# Altium schematic sheet vibration_sensor.SchDoc
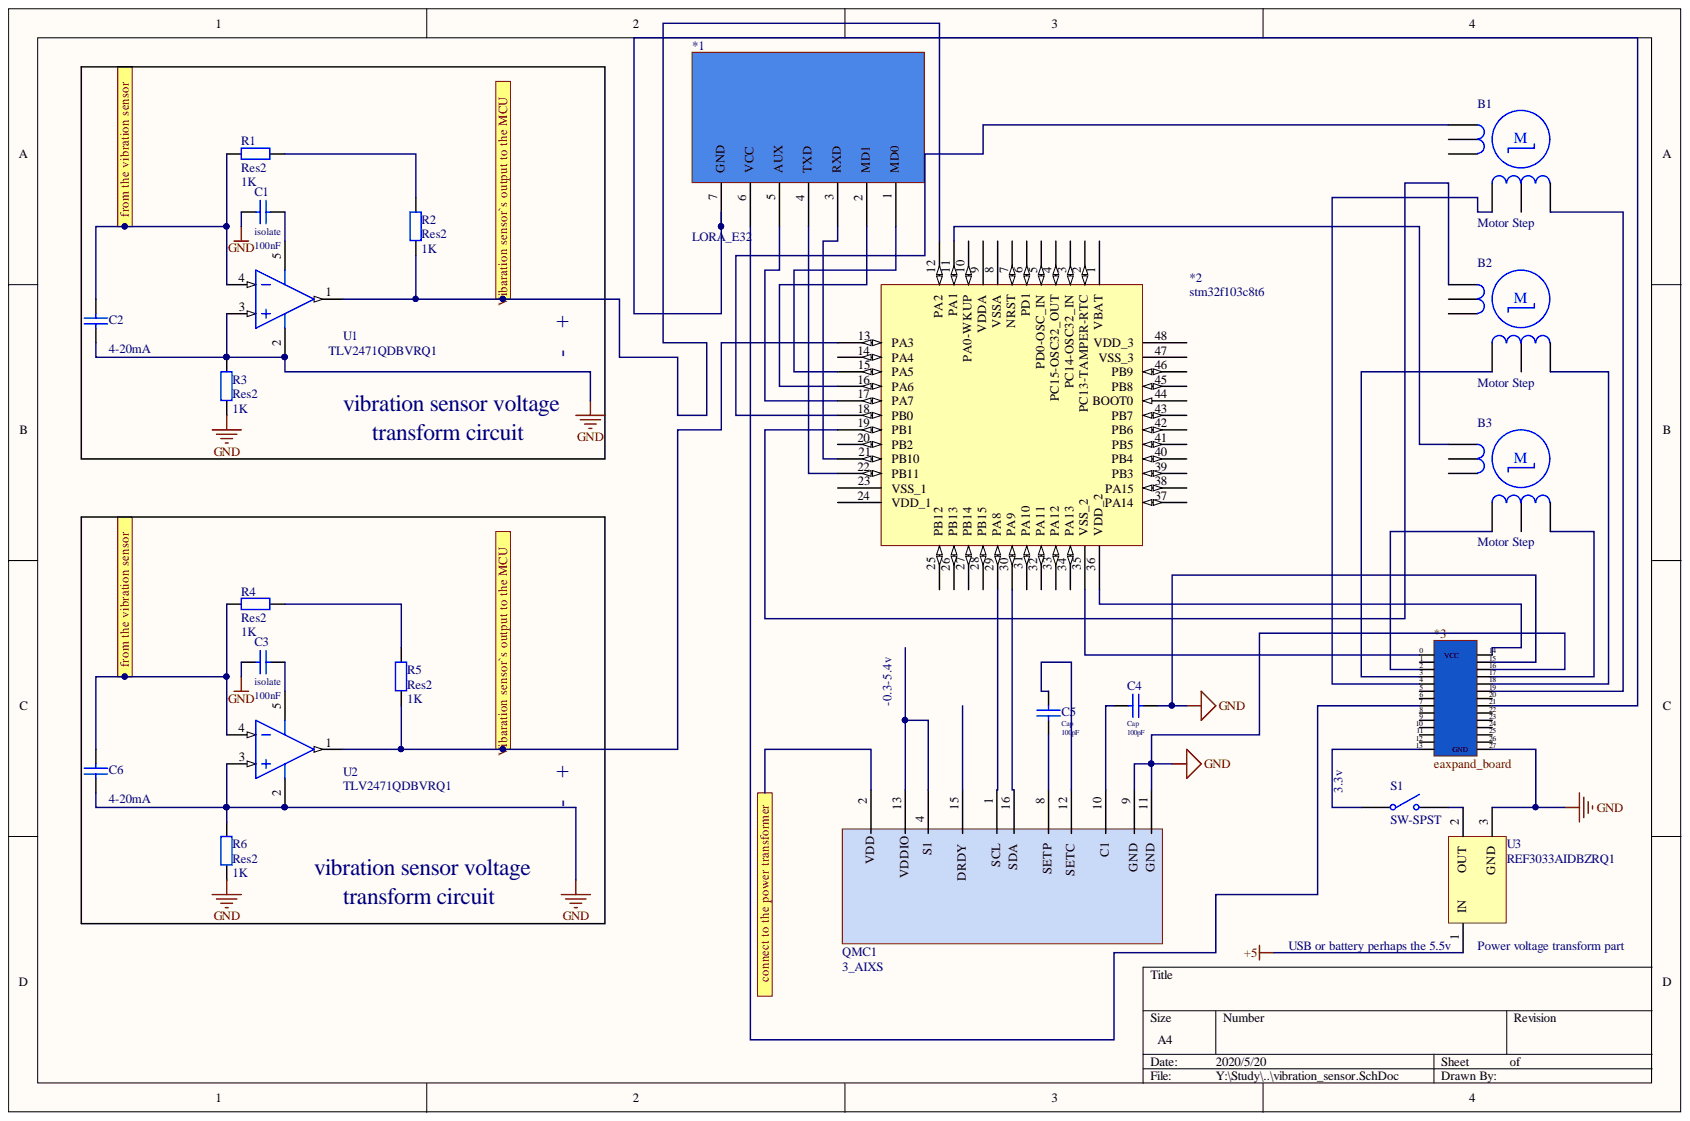
[diagram p0: vibration_sensor.SchDoc]

## Parts
*1  LORA_E32  [LORA_AS62-T20]
*2  stm32f103c8t6
*3  eaxpand_board  [expand_board]
B1  Motor Step  [DIP-6]
B2  Motor Step  [DIP-6]
B3  Motor Step  [DIP-6]
C1  isolate  value 100nF  [RAD-0.3]
C2  value 4-20mA  [RAD-0.3]  (hidden comment: 假装这是一个电流源)
C3  isolate  value 100nF  [RAD-0.3]
C4  Cap  value 100pF  [RAD-0.3]
C5  Cap  value 100pF  [RAD-0.3]
C6  value 4-20mA  [RAD-0.3]  (hidden comment: 假装这是一个电流源)
QMC1  3_AIXS
R1  Res2  value 1K  [AXIAL-0.4]
R2  Res2  value 1K  [AXIAL-0.4]
R3  Res2  value 1K  [AXIAL-0.4]
R4  Res2  value 1K  [AXIAL-0.4]
R5  Res2  value 1K  [AXIAL-0.4]
R6  Res2  value 1K  [AXIAL-0.4]
S1  SW-SPST  [SPST-2]
U1  TLV2471QDBVRQ1  [TI-DBV5_N]
U2  TLV2471QDBVRQ1  [TI-DBV5_N]
U3  REF3033AIDBZRQ1  [TI-DBZ3_N]

## Ports
connect to the power transformer, from the vibration sensor, Vibaration sensor`s output to the MCU

## Nets
+5: U3.1
GND: *3.15 *3.16 *3.27(GND) C1.2 C2.1 C3.2 C4.1 C6.1 QMC1.9(GND) QMC1.11(GND) R3.1 R3.2 R6.1 R6.2 U1.2 U1.3 U2.2 U2.3 U3.3
connect to the power transformer: QMC1.2(VDD) ; port connect to the power transformer
from the vibration sensor: C2.2 C6.2 R1.1 R4.1 U1.4 U2.4 ; port from the vibration sensor
Vibaration sensor`s output to the MCU: *2.12(PA2) *2.13(PA3) R2.1 R5.1 U1.1 U2.1 ; port Vibaration sensor`s output to the MCU
Net*1_1: *1.1(MD0) *2.15(PA5)
Net*1_2: *1.2(MD1) *2.16(PA6)
Net*1_3: *1.3(RXD) *2.21(PB10)
Net*1_4: *1.4(TXD) *2.22(PB11)
Net*1_5: *1.5(AUX) *2.17(PA7)
Net*1_6: *1.6(VCC) *3.7
Net*1_7: *1.7(GND) *3.21
Net*2_11: *2.11(PA1) B3.1
Net*2_18: *2.18(PB0) B1.1
Net*2_19: *2.19(PB1) B2.1
Net*2_29: *2.29(PA8) QMC1.1(SCL)
Net*2_30: *2.30(PA9) QMC1.16(SDA)
Net*2_35: *2.35(VSS_2) *3.0(VCC)
Net*2_36: *2.36(VDD_2) *3.14
Net*3_2: *3.2 B3.4
Net*3_3: *3.3 B2.4
Net*3_4: *3.4 B1.4
Net*3_13: *3.13 S1.1
Net*3_17: *3.17 B3.6
Net*3_18: *3.18 B2.6
Net*3_19: *3.19 B1.6
NetC1_1: C1.1 U1.5
NetC3_1: C3.1 U2.5
NetC4_2: C4.2 QMC1.10(C1)
NetC5_1: C5.1 QMC1.8(SETP)
NetC5_2: C5.2 QMC1.12(SETC)
NetQMC1_4: QMC1.4(S1) QMC1.13(VDDIO)
NetR1_2: R1.2 R2.2
NetR4_2: R4.2 R5.2
NetS1_2: S1.2 U3.2

Not connected: *2 1(VBAT) 2(PC13-TAMPER-RTC) 3(PC14-OSC32_IN) 4(PC15-OSC32_OUT) 5(PD0-OSC_IN) 6(PD1) 7(NRST) 8(VSSA) 9(VDDA) 10(PA0-WKUP) 14(PA4) 20(PB2) 23(VSS_1) 24(VDD_1) 25(PB12) 26(PB13) 27(PB14) 28(PB15) 31(PA10) 32(PA11) 33(PA12) 34(PA13) 37(PA14) 38(PA15) 39(PB3) 40(PB4) 41(PB5) 42(PB6) 43(PB7) 44(BOOT0) 45(PB8) 46(PB9) 47(VSS_3) 48(VDD_3); *3 1 5 6 8 9 10 11 12 20 22 23 24 25 26; B1 2 3 5; B2 2 3 5; B3 2 3 5; QMC1 15(DRDY)

## Library data of the parts
*1 = LORA_E32: EBYTE_USE_SEMTCH_CORE; Component Kind: Standard; Library Name: lora.SchLib
B1-B3 = Motor Step: Motor Stepper; Code_JEDEC: MO-001-AM; Component Kind: Standard; LatestRevisionDate: 17-Jul-2002; LatestRevisionNote: Re-released for DXP Platform.; Library Name: Miscellaneous Devices.IntLib; PackageReference: DIP-6; Published: 24-Mar-1999; Publisher: Altium Limited
C1 C3 = Cap: Capacitor; Value: 100nF; Component Kind: Standard; LatestRevisionDate: 17-Jul-2002; LatestRevisionNote: Re-released for DXP Platform.; Library Name: Miscellaneous Devices.IntLib; PackageDescription: Radial Cap, Thru-Hole; 2 Leads; 0.3 in Pin Spacing; PackageReference: RAD-0.3; Published: 8-Jun-2000; Signal Integrity: Cap; Simulation: CAP; Publisher: Altium Limited
C2 C4-C6 = Cap: Capacitor; Component Kind: Standard; LatestRevisionDate: 17-Jul-2002; LatestRevisionNote: Re-released for DXP Platform.; Library Name: Miscellaneous Devices.IntLib; PackageDescription: Radial Cap, Thru-Hole; 2 Leads; 0.3 in Pin Spacing; PackageReference: RAD-0.3; Published: 8-Jun-2000; Signal Integrity: Cap; Simulation: CAP; Publisher: Altium Limited
QMC1 = QMC5883L: Component Kind: Standard; Library Name: QMC5883L.SchLib
R1 R4 = Res2: Resistor; Value: 1K; Component Kind: Standard; LatestRevisionDate: 17-Jul-2002; LatestRevisionNote: Re-released for DXP Platform.; Library Name: Miscellaneous Devices.IntLib; PackageDescription: Axial Device, Thru-Hole; 2 Leads; 0.4 in Pin Spacing; PackageReference: AXIAL-0.4; Published: 8-Jun-2000; Signal Integrity: Res; Simulation: RESISTOR; Publisher: Altium Limited
R2 R3 R5 R6 = Res2: Resistor; Component Kind: Standard; LatestRevisionDate: 17-Jul-2002; LatestRevisionNote: Re-released for DXP Platform.; Library Name: Miscellaneous Devices.IntLib; PackageDescription: Axial Device, Thru-Hole; 2 Leads; 0.4 in Pin Spacing; PackageReference: AXIAL-0.4; Published: 8-Jun-2000; Signal Integrity: Res; Simulation: RESISTOR; Publisher: Altium Limited
S1 = SW-SPST: Single-Pole, Single-Throw Switch; Component Kind: Standard; LatestRevisionDate: 17-Jul-2002; LatestRevisionNote: Re-released for DXP Platform.; Library Name: Miscellaneous Devices.IntLib; PackageDescription: Switch; 2 Leads; PackageReference: SPST-2; Published: 24-Mar-1999; Publisher: Altium Limited
U1 U2 = TLV2471QDBVRQ1: Automotive Catalog 600 uA/Ch 2.8 MHz Rail-to-Rail Input / Output High-Drive Operational Amplifier, 2.7 to 6 V, -40 to 125 degC, 5-pin SOT23 (DBV5), Green (RoHS & no Sb/Br); Manufacturer: Texas Instruments; CMRR(Min)(dB): 58; GBW(Typ)(MHz): 2.8; IIB(Max)(pA): 50; Iq per channel(Max)(mA): 0.75; Number of Channels: 1; Offset Drift(Typ)(uV/C): 0.4; Packing: LARGE T&R; Slew Rate(Typ)(V/us): 1.4; Sub Family: Low Supply Voltage Amplifier (<=2.7V), Precision Amplifier; Total Supply Voltage(Max)(+5V is 5, +/-5V is 10): 6; Total Supply Voltage(Min)(+5V is 5, +/-5V is 10): 2.7; Vio (25C)(Max)(mV): 2.2; Code_JEDEC: MO-178; Component Kind: Standard; ComponentLink1Description: Manufacturer URL; ComponentLink1URL: http://www.ti.com/; ComponentLink2Description: Datasheet; ComponentLink2URL: http://www.ti.com/lit/ds/symlink/tlv2471-q1.pdf; ComponentLink3Description: Package Specification; ComponentLink3URL: http://www.ti.com/litv/pdf/mpds018i; DatasheetVersion: SGLS180B; Eco-Plan: Green (RoHS & no Sb/Br); Mounting Technology: Surface Mount; PackageDescription: 5-Pin Plastic Small Outline, Body 1.6 x 2.9 mm, Pitch 0.95 mm; PackageReference: DBV5; PackageVersion: 4073253-4/K, 03/2006; PartNumber: TLV2471QDBVRQ1; Rating: Automotive; Library Name: TI Amplifiers and Linear Operational Amplifier Precision Amplifier Part Two.IntLib
U3 = REF3033AIDBZRQ1: Automotive Catalog, 3.3V 50 ppm / degC, 50 uA in Series (Bandgap) Voltage Reference, -40 to 85 degC, 3-pin SOT-23 (DBZ), Green (RoHS & no Sb/Br); Manufacturer: Texas Instruments; Initial Accuracy @ 25/deg C(%): 0.2; SubFamily: Series Voltage Reference; Temp Coeff(Max)(ppm/ degree C): 65; Temp Coeff(Typ)(ppm/ degree C): 30; VI(Max)(V): 5.5; VO(V): 3.3; Code_JEDEC: TO-236; Component Kind: Standard; ComponentLink1Description: Manufacturer URL; ComponentLink1URL: http://www.ti.com/; ComponentLink2Description: Datasheet; ComponentLink2URL: http://www.ti.com/general/docs/lit/getliterature.tsp?genericPartNumber=REF3033-Q1&fileType=pdf; ComponentLink3Description: Package Specification; ComponentLink3URL: http://www.ti.com/litv/pdf/mpds108a; DatasheetVersion: SBVS131; Eco-Plan: Green (RoHS & no Sb/Br); Library Name: TI Power Management Series Voltage Reference.IntLib; Mounting Technology: Surface Mount; PackageDescription: 3-Pin Plastic Small Outline, Body 2.92 x 1.3 mm, Pitch 0.95 mm; PackageReference: DBZ3; PackageVersion: 4203227/B; PartNumber: REF3033AIDBZRQ1; Rating: Automotive; Supplier 1: Digi-Key; Supplier 2: Digi-Key; Supplier 3: Digi-Key; Supplier 4: Newark; Supplier 5: Mouser; Supplier Part Number 1: 296-27477-2-ND; Supplier Part Number 2: 296-27477-1-ND; Supplier Part Number 3: 296-27477-6-ND; Supplier Part Number 4: 79R8280; Supplier Part Number 5: 595-REF3033AIDBZRQ1
*2 = stm32f103c8t6: Component Kind: Standard; Library Name: stm32f103c8t6.SchLib
*3 = eaxpand_board: Component Kind: Standard; Library Name: My_library.IntLib
